# Altium schematic sheet Dual_SPD5651E.SchDoc
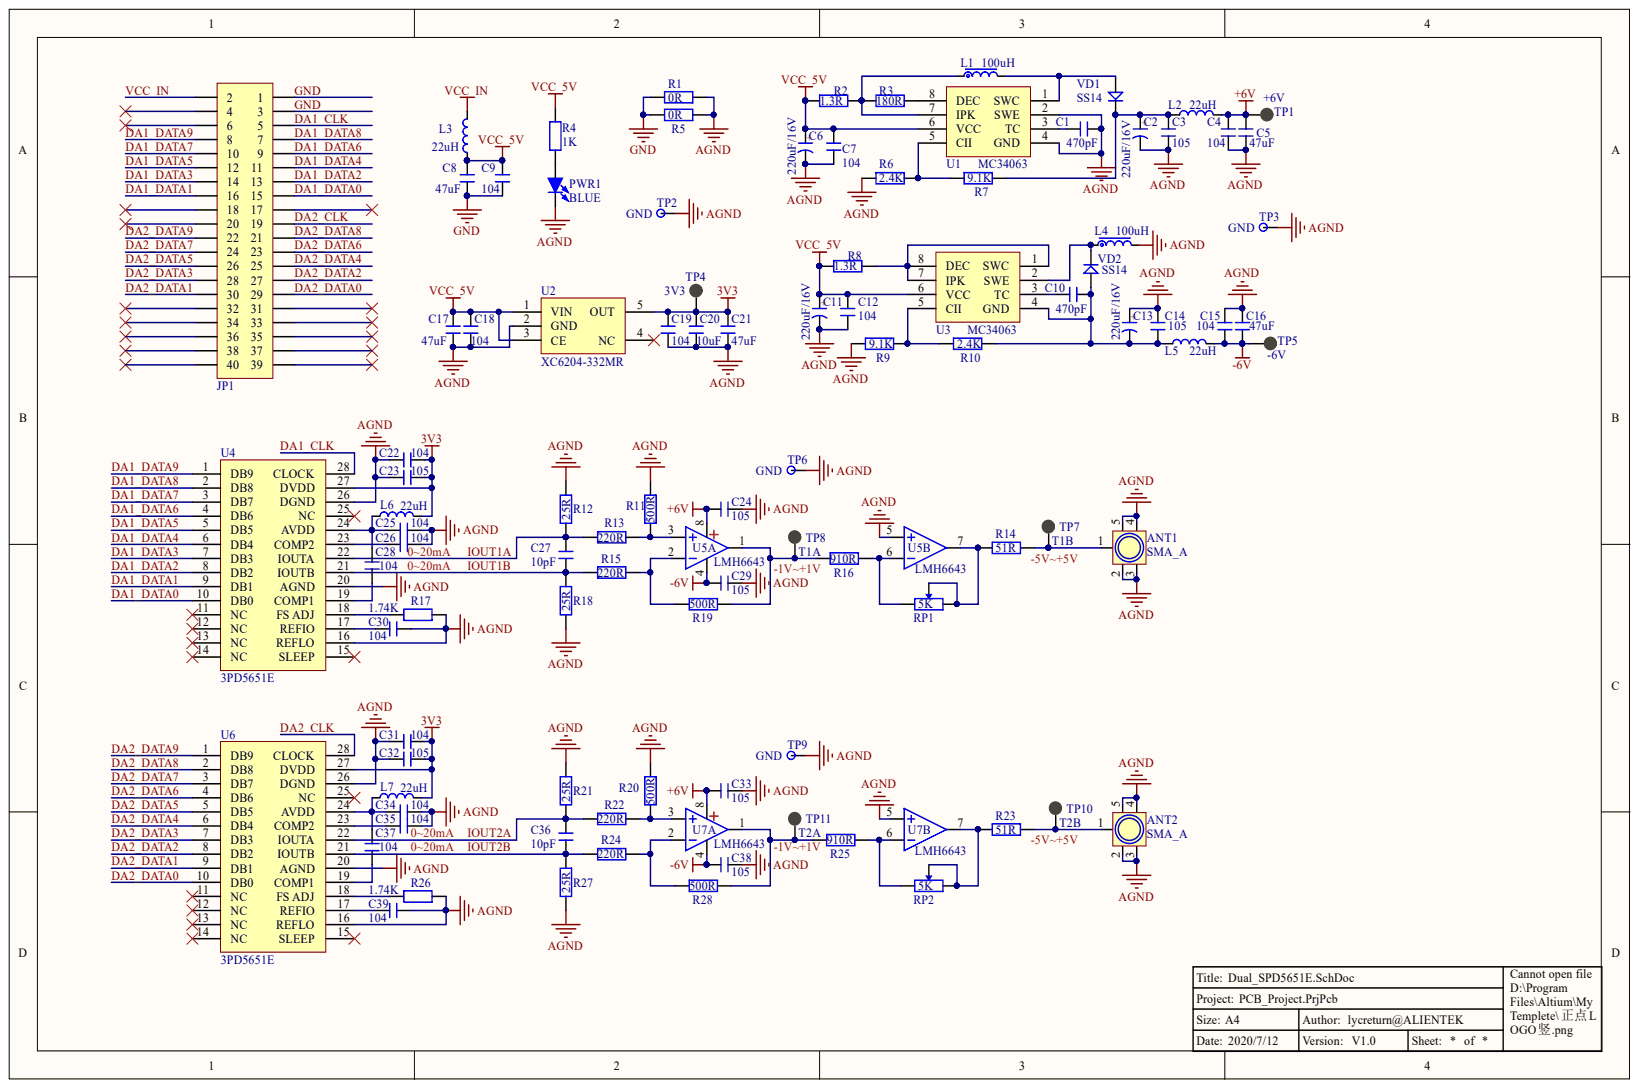
[diagram p0: Dual_SPD5651E.SchDoc]

## Parts
ANT1  SMA_A  [SMA_KWE]
ANT2  SMA_A  [SMA_KWE]
C1  470pF  [C 0603]
C2  220uF/16V  [CM D(6.3*5.4)]
C3  105  [C 0603]
C4  104  [C 0603]
C5  47uF  [C 0805]
C6  220uF/16V  [CM D(6.3*5.4)]
C7  104  [C 0603]
C8  47uF  [C 0805]
C9  104  [C 0603]
C10  470pF  [C 0603]
C11  220uF/16V  [CM D(6.3*5.4)]
C12  104  [C 0603]
C13  220uF/16V  [CM D(6.3*5.4)]
C14  105  [C 0603]
C15  104  [C 0603]
C16  47uF  [C 0805]
C17  47uF  [C 0805]
C18  104  [C 0603]
C19  104  [C 0603]
C20  10uF  [C 0603]
C21  47uF  [C 0805]
C22  104  [C 0603]
C23  105  [C 0603]
C24  105  [C 0603]
C25  104  [C 0603]
C26  104  [C 0603]
C27  10pF  [C 0603]
C28  104  [C 0603]
C29  105  [C 0603]
C30  104  [C 0603]
C31  104  [C 0603]
C32  105  [C 0603]
C33  105  [C 0603]
C34  104  [C 0603]
C35  104  [C 0603]
C36  10pF  [C 0603]
C37  104  [C 0603]
C38  105  [C 0603]
C39  104  [C 0603]
JP1  Header 2X11  [HDR-20X2]  (value not drawn)
L1  100uH  [CDRH74]
L2  22uH  [L 0603]
L3  22uH  [L 0603]
L4  100uH  [CDRH74]
L5  22uH  [L 0603]
L6  22uH  [L 0603]
L7  22uH  [L 0603]
PWR1  BLUE  [LED 0805B]
R1  0R  [R 0603]
R2  1.3R  [R 0603]
R3  180R  [R 0603]
R4  1K  [R 0603]
R5  0R  [R 0603]
R6  2.4K  [R 0603]
R7  9.1K  [R 0603]
R8  1.3R  [R 0603]
R9  9.1K  [R 0603]
R10  2.4K  [R 0603]
R11  500R  [R 0603]
R12  25R  [R 0603]
R13  220R  [R 0603]
R14  51R  [R 0603]
R15  220R  [R 0603]
R16  910R  [R 0603]
R17  1.74K  [R 0603]
R18  25R  [R 0603]
R19  500R  [R 0603]
R20  500R  [R 0603]
R21  25R  [R 0603]
R22  220R  [R 0603]
R23  51R  [R 0603]
R24  220R  [R 0603]
R25  910R  [R 0603]
R26  1.74K  [R 0603]
R27  25R  [R 0603]
R28  500R  [R 0603]
RP1  5K  [XV09223NPV-25F]
RP2  5K  [XV09223NPV-25F]
TP1  +6V  [TEST 1]
TP2  GND  [TEST TP1.4_B]
TP3  GND  [TEST TP1.4_B]
TP4  3V3  [TEST 1]
TP5  -6V  [TEST 1]
TP6  GND  [TEST TP1.4_B]
TP7  T1B  [TEST 1]
TP8  T1A  [TEST 1]
TP9  GND  [TEST TP1.4_B]
TP10  T2B  [TEST 1]
TP11  T2A  [TEST 1]
U1  MC34063  [SOP8_N]
U2  XC6204-332MR  [SOT23-5N]
U3  MC34063  [SOP8_N]
U4  3PD5651E  [TSSOP28_N]
U5  LMH6643  [SOP8_N]  (parts A,B)
U6  3PD5651E  [TSSOP28_N]
U7  LMH6643  [SOP8_N]  (parts A,B)
VD1  SS14  [SMA]
VD2  SS14  [SMA]

## Nets
3V3: C19.2 C20.2 C21.2 C22.1 C23.1 C31.1 C32.1 L6.1 L7.1 TP4.1 U2.5(OUT) U4.27(DVDD) U6.27(DVDD)
+6V: C4.2 C5.2 C24.1 C33.1 L2.1 TP1.1 U5.8 U7.8
-6V: C15.1 C16.1 C29.1 C38.1 L5.1 TP5.1 U5.4 U7.4
AGND: ANT1.2 ANT1.3 ANT1.4 ANT1.5 ANT2.2 ANT2.3 ANT2.4 ANT2.5 C1.1 C2.2 C3.1 C4.1 C5.1 C6.2 C7.1 C11.2 C12.1 C13.1 C14.2 C15.2 C16.2 C17.1 C18.1 C19.1 C20.1 C21.1 C22.2 C23.2 C24.2 C25.2 C26.2 C29.2 C30.2 C31.2 C32.2 C33.2 C34.2 C35.2 C38.2 C39.2 L4.2 PWR1.2 R1.2 R5.2 R6.2 R9.2 R11.2 R12.2 R17.2 R18.1 R20.2 R21.2 R26.2 R27.1 TP2.1 TP3.1 TP6.1 TP9.1 U1.2(SWE) U1.4(GND) U2.2(GND) U4.16(REFLO) U4.20(AGND) U4.26(DGND) U5.5 U6.16(REFLO) U6.20(AGND) U6.26(DGND) U7.5
VCC_5V: C6.1 C7.2 C8.2 C9.2 C11.1 C12.2 C17.2 C18.2 L3.2 R2.2 R4.1 R8.2 U1.6(VCC) U2.1(VIN) U2.3(CE) U3.6(VCC)
DA1_CLK: JP1.5 U4.28(CLOCK)
DA1_DATA0: JP1.15 U4.10(DB0)
DA1_DATA1: JP1.16 U4.9(DB1)
DA1_DATA2: JP1.13 U4.8(DB2)
DA1_DATA3: JP1.14 U4.7(DB3)
DA1_DATA4: JP1.11 U4.6(DB4)
DA1_DATA5: JP1.12 U4.5(DB5)
DA1_DATA6: JP1.9 U4.4(DB6)
DA1_DATA7: JP1.10 U4.3(DB7)
DA1_DATA8: JP1.7 U4.2(DB8)
DA1_DATA9: JP1.8 U4.1(DB9)
DA2_CLK: JP1.19 U6.28(CLOCK)
DA2_DATA0: JP1.29 U6.10(DB0)
DA2_DATA1: JP1.30 U6.9(DB1)
DA2_DATA2: JP1.27 U6.8(DB2)
DA2_DATA3: JP1.28 U6.7(DB3)
DA2_DATA4: JP1.25 U6.6(DB4)
DA2_DATA5: JP1.26 U6.5(DB5)
DA2_DATA6: JP1.23 U6.4(DB6)
DA2_DATA7: JP1.24 U6.3(DB7)
DA2_DATA8: JP1.21 U6.2(DB8)
DA2_DATA9: JP1.22 U6.1(DB9)
GND: C8.1 C9.1 JP1.1 JP1.3 R1.1 R5.1
IOUT1A: C27.2 R12.1 R13.2 U4.22(IOUTA)
IOUT1B: C27.1 R15.2 R18.2 U4.21(IOUTB)
IOUT2A: C36.2 R21.1 R22.2 U6.22(IOUTA)
IOUT2B: C36.1 R24.2 R27.2 U6.21(IOUTB)
VCC_IN: JP1.2 L3.1
NetANT1_1: ANT1.1 R14.1 TP7.1
NetANT2_1: ANT2.1 R23.1 TP10.1
NetC1_2: C1.2 U1.3(TC)
NetC2_1: C2.1 C3.2 L2.2 R7.1 VD1.2
NetC10_1: C10.1 C13.2 C14.1 L5.2 R10.1 U3.4(GND) VD2.1
NetC10_2: C10.2 U3.3(TC)
NetC25_1: C25.1 C28.2 L6.2 U4.24(AVDD)
NetC26_1: C26.1 U4.23(COMP2)
NetC28_1: C28.1 U4.19(COMP1)
NetC30_1: C30.1 U4.17(REFIO)
NetC34_1: C34.1 C37.2 L7.2 U6.24(AVDD)
NetC35_1: C35.1 U6.23(COMP2)
NetC37_1: C37.1 U6.19(COMP1)
NetC39_1: C39.1 U6.17(REFIO)
NetL1_1: L1.1 R2.1 R3.1 U1.7(IPK)
NetL1_2: L1.2 U1.1(SWC) VD1.1
NetL4_1: L4.1 U3.2(SWE) VD2.2
NetPWR1_1: PWR1.1 R4.2
NetR3_2: R3.2 U1.8(DEC)
NetR6_1: R6.1 R7.2 U1.5(CII)
NetR8_1: R8.1 U3.1(SWC) U3.7(IPK) U3.8(DEC)
NetR9_1: R9.1 R10.2 U3.5(CII)
NetR11_1: R11.1 R13.1 U5.3
NetR14_2: R14.2 RP1.2 RP1.3 U5.7
NetR15_1: R15.1 R19.2 U5.2
NetR16_1: R16.1 RP1.1 U5.6
NetR16_2: R16.2 R19.1 TP8.1 U5.1
NetR17_1: R17.1 U4.18(FS_ADJ)
NetR20_1: R20.1 R22.1 U7.3
NetR23_2: R23.2 RP2.2 RP2.3 U7.7
NetR24_1: R24.1 R28.2 U7.2
NetR25_1: R25.1 RP2.1 U7.6
NetR25_2: R25.2 R28.1 TP11.1 U7.1
NetR26_1: R26.1 U6.18(FS_ADJ)

Not connected: JP1 4 6 17 18 20 31 32 33 34 35 36 37 38 39 40; U2 4(NC); U4 11(NC) 12(NC) 13(NC) 14(NC) 15(SLEEP) 25(NC); U6 11(NC) 12(NC) 13(NC) 14(NC) 15(SLEEP) 25(NC)

## Library data of the parts
ANT1 ANT2 = SMA_A: SMA-射频接口; BOM名称: SMA-2.4G射频天线接口; 版权归属: 源创客; Component Kind: Standard; Library Name: 接口类.SchLib; 学习资源: www.ooocke.com
C1 C3-C5 C7-C10 C12 C14-C39 = C: 无极性贴片电容; 版权归属: 源创客; BOM名称: 无极性贴片电容; Component Kind: Standard; 学习资源: www.ooocke.com; Library Name: Lycreturn.IntLib
C2 C6 C11 C13 = C-CM: 贴片电解电容; 版权归属: 源创客; BOM名称: 贴片电解电容; Component Kind: Standard; Library Name: 电阻-电容-电感.SchLib; 学习资源: www.ooocke.com
JP1 = Header 20X2: 20*2P接插件; 版权归属: 源创客; BOM名称: 20*2P接插件; Component Kind: Standard; Library Name: 接插件.SchLib; 学习资源: www.ooocke.com
L1 L4 = L-CDRH: 功率屏蔽电感; 版权归属: 源创客; BOM名称: 功率屏蔽电感; Component Kind: Standard; Library Name: 电阻-电容-电感.SchLib; 学习资源: www.ooocke.com
L2 L3 L5-L7 = L: 小功率贴片电感磁珠; 版权归属: 源创客; BOM名称: 小功率贴片电感; Component Kind: Standard; Library Name: 电阻-电容-电感.SchLib; 学习资源: www.ooocke.com
PWR1 = LED-SMD: 贴片LED; 版权归属: 源创客; BOM名称: 贴片LED; Component Kind: Standard; Library Name: LED.SchLib; 学习资源: www.ooocke.com
R1-R28 = R: 贴片电阻; 版权归属: 源创客; BOM名称: 贴片电阻; Component Kind: Standard; 学习资源: www.ooocke.com; Library Name: Lycreturn.IntLib
RP1 RP2 = RP-ID: 插件单联电位器; BOM名称: 插件电位器; 版权归属: 源创客; Component Kind: Standard; Library Name: 电阻-电容-电感.SchLib; 学习资源: www.ooocke.com
TP1 TP4 TP5 TP7 TP8 TP10 TP11 = TEST: 测试点; 版权归属: 源创客; BOM名称: 测试点; Component Kind: Standard; Library Name: 未分类器件.SchLib; 学习资源: www.ooocke.com
TP2 TP3 TP6 TP9 = TEST TP: 测试环; 版权归属: 源创客; BOM名称: 测试环; Component Kind: Standard; Library Name: 未分类器件.SchLib; 学习资源: www.ooocke.com
U1 U3 = DC MC34063: DC升降压芯片; 版权归属: 源创客; BOM名称: DC升降压芯片; Component Kind: Standard; Library Name: LDO和DCDC.SchLib; 学习资源: www.ooocke.com
U2 = LDO XC6204: LDO; BOM名称: 稳压芯片; 版权归属: 源创客; Component Kind: Standard; Library Name: LDO和DCDC.SchLib; 学习资源: www.ooocke.com
U4 U6 = 3PD5651E: 高速DA 125M 10bit; BOM名称: 音频PCM编解码芯片; 版权归属: 源创客; Component Kind: Standard; Library Name: IC芯片.SchLib; 学习资源: www.ooocke.com
U5 U7 = SGM8052: 双路运放 250MHz; 版权归属: 源创客; BOM名称: 双路运放; Component Kind: Standard; Library Name: IC芯片.SchLib; 学习资源: www.ooocke.com
VD1 VD2 = SS14: 肖特基二极管; 属性: 40V/1A; 版权归属: 源创客; BOM名称: 肖特基二极管; Component Kind: Standard; Library Name: 二极管-整流桥.SchLib; 学习资源: www.ooocke.com
